# Altium schematic sheet mppt_relays.SchDoc
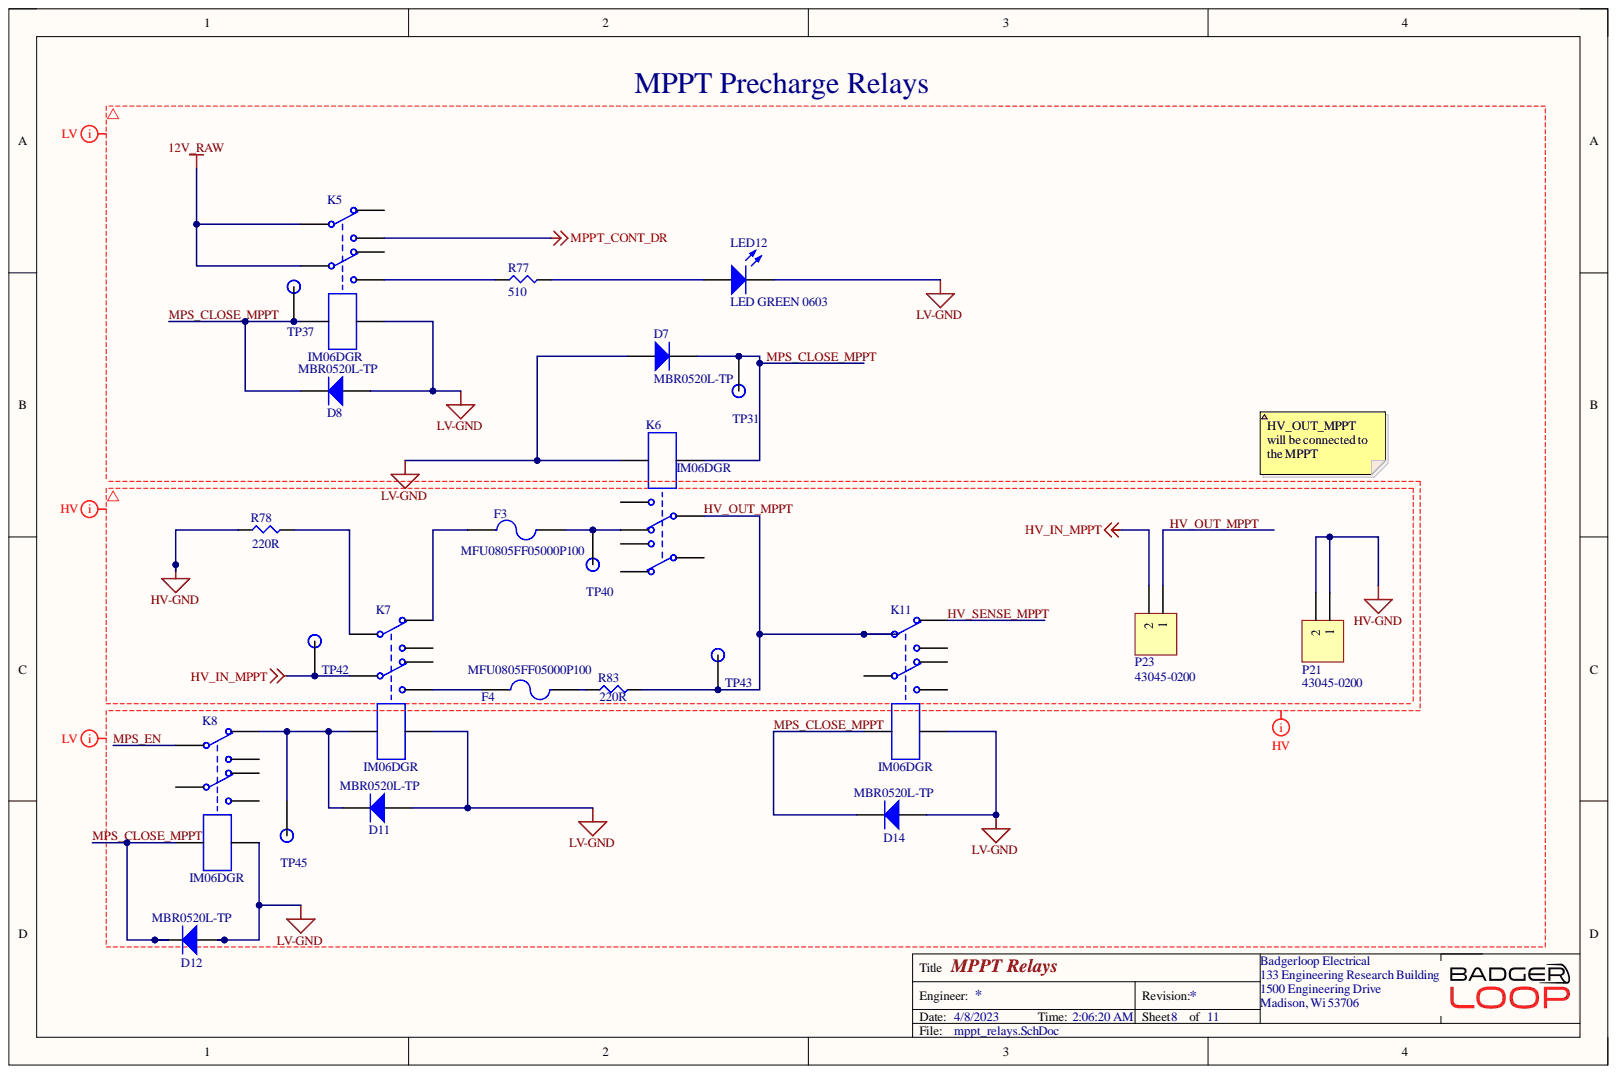
[diagram p0: mppt_relays.SchDoc]

## Parts
D7  MBR0520L-TP  [MBR0520LT1G - SOD123]
D8  MBR0520L-TP  [MBR0520LT1G - SOD123]
D11  MBR0520L-TP  [MBR0520LT1G - SOD123]
D12  MBR0520L-TP  [MBR0520LT1G - SOD123]
D14  MBR0520L-TP  [MBR0520LT1G - SOD123]
F3  MFU0805FF05000P100  [SMD-0805-RES]
F4  MFU0805FF05000P100  [SMD-0805-RES]
K5  Manufacturer Part Number: IM06DGR  [1-1462039-8 - relay]  (hidden comment: 1-1462039-8)
K6  Manufacturer Part Number: IM06DGR  [1-1462039-8 - relay]  (hidden comment: 1-1462039-8)
K7  Manufacturer Part Number: IM06DGR  [1-1462039-8 - relay]  (hidden comment: 1-1462039-8)
K8  Manufacturer Part Number: IM06DGR  [1-1462039-8 - relay]  (hidden comment: 1-1462039-8)
K11  Manufacturer Part Number: IM06DGR  [1-1462039-8 - relay]  (hidden comment: 1-1462039-8)
LED12  LED GREEN 0603  Color: GREEN  [LED 0603 GREEN]
P21  43045-0200  [430450200]
P23  43045-0200  [430450200]
R77  RMCF1206FT510R  [SMD-1206-RES]  (value not drawn)
R78  Resistance: 220R  [TO-247-2]  (hidden comment: AP101220RJ)
R83  Resistance: 220R  [TO-247-2]  (hidden comment: AP101220RJ)
TP31  TP  [Test Point]
TP37  TP  [Test Point]
TP40  TP  [Test Point]
TP42  TP  [Test Point]
TP43  TP  [Test Point]
TP45  TP  [Test Point]

## Nets
12V_RAW: K5.3 K5.6
HV-GND: P21.1 P21.2 R78.1
HV_IN_MPPT: K7.6 P23.2 TP42.1
LV-GND: D7.1 D8.1 D11.1 D12.1 D14.1 K5.8 K6.8 K7.8 K8.8 K11.8 LED12.2
MPPT_CONT_DR: K5.4
HV_OUT_MPPT: K6.6 K11.3 P23.1 R83.2 TP43.1
HV_SENSE_MPPT: K11.2
MPS_CLOSE_MPPT: D7.2 D8.2 D12.2 D14.2 K5.1 K6.1 K8.1 K11.1 TP31.1 TP37.1
MPS_EN: K8.3
NetD11_2: D11.2 K7.1 K8.2 TP45.1
NetF3_1: F3.1 K7.2
NetF3_2: F3.2 K6.7 TP40.1
NetF4_1: F4.1 K7.5
NetF4_2: F4.2 R83.1
NetK5_5: K5.5 R77.1
NetK7_3: K7.3 R78.2
NetLED12_1: LED12.1 R77.2

Not connected: K5 2 7; K6 2 3 4 5; K7 4 7; K8 4 5 6 7; K11 4 5 6 7

## Library data of the parts
D7 D8 D11 D12 D14 = MBR0520L-TP: Rectifier Diode, Schottky, 1 Phase, 1 Element, 0.5A, 20V V(RRM), Silicon; Manufacturer: MCC; Forward Voltage-Max (VF): 300mV; Max Output Current: 500mA; Max Power Dissipation: 410mW; Non-rep Pk Forward Current-Max: 5.5A; Number of Elements: 1; Package Body Material: Plastic; Qualification Status: Not Qualified; Time @ Peak Reflow Temperature-Max (s): 10s; Category: Rectifier Diodes; Component Kind: Standard; ComponentLink1Description: https://datasheet.ciiva.com:443/pdfs/VipMasterIC/IC/MCCC/MCCC-S-A0007607609/MCCC-S-A0007607609-1.pdf?src-supplier=IHS+Markit; ComponentLink1URL: https://datasheet.ciiva.com:443/pdfs/VipMasterIC/IC/MCCC/MCCC-S-A0007607609/MCCC-S-A0007607609-1.pdf?src-supplier=IHS+Markit; ComponentLink2Description: https://datasheet.ciiva.com/24106/mbr0520lmbr0540lsod-123-276512-24106653.pdf?src-supplier=Mouser; ComponentLink2URL: https://datasheet.ciiva.com/24106/mbr0520lmbr0540lsod-123-276512-24106653.pdf?src-supplier=Mouser; ComponentLink3Description: https://datasheet.ciiva.com/6381/mbr0520lmbr0540lsod-123-6381109.pdf?src-supplier=Digi-Key; ComponentLink3URL: https://datasheet.ciiva.com/6381/mbr0520lmbr0540lsod-123-6381109.pdf?src-supplier=Digi-Key; Diode Element Material: SILICON; Diode Type: RECTIFIER; JESD-30 Code: R-PDSO-G2; JESD-609 Code: e3; Library Name: misc.SchLib; Manufacturer Part Number: MBR0520L-TP; Max Operating Temperature: 125°C; Moisture Sensitivity Level: 1; Number of Pins: 2; Package Shape: Rectangular; Package Style: SMALL OUTLINEMeter; Peak Reflow Temperature (Cel): 260°C; Pricing 1: 1=0.52000, 10=0.38700, 100=0.21930, 500=0.14520, 1000=0.11132 (USD); REACH SVHC: Yes; Rep Pk Reverse Voltage-Max: 20V; RoHS: Yes; RoHS Compliant: Yes; Stock 1: 75810; Supplier 1: Digi-Key; Supplier Part Number 1: MBR0520L-TPMSCT-ND; Surface Mount: Yes; Technology: SCHOTTKY; Terminal Finish: Tin; Terminal Form: Gull Wing; Terminal Position: DUAL
F3 F4 = MFU0805FF05000P100: Electric Fuse, Very Fast Blow, 5A, 32VDC, 50A (IR), Surface Mount; Manufacturer: Vishay; Body Breadth: 1.25inch; Body Height: 0.45mm; Body Length or Diameter: 2mm; Joule-integral-Nom: 0.2275J; Reference Standard: UL; Voltage Rating (DC): 32V; Blow Characteristic: VERY FAST; Category: Fuses; Circuit Protection Type: Electric Fuse; Component Kind: Standard; ComponentLink1Description: https://datasheet.ciiva.com:443/pdfs/VipMasterIC/IC/VISH/VISH-S-A0001809179/VISH-S-A0001809179-1.pdf?src-supplier=IHS+Markit; ComponentLink1URL: https://datasheet.ciiva.com:443/pdfs/VipMasterIC/IC/VISH/VISH-S-A0001809179/VISH-S-A0001809179-1.pdf?src-supplier=IHS+Markit; ComponentLink2Description: https://datasheet.ciiva.com/1730/mfuserie-1730720.pdf?src-supplier=Newark; ComponentLink2URL: https://datasheet.ciiva.com/1730/mfuserie-1730720.pdf?src-supplier=Newark; ComponentLink3Description: https://datasheet.ciiva.com/11337/mfuserie-11337721.pdf?src-supplier=Avnet; ComponentLink3URL: https://datasheet.ciiva.com/11337/mfuserie-11337721.pdf?src-supplier=Avnet; ComponentLink4Description: https://datasheet.ciiva.com/8057/1480005-8057917.pdf?src-supplier=Element14; ComponentLink4URL: https://datasheet.ciiva.com/8057/1480005-8057917.pdf?src-supplier=Element14; ComponentLink5Description: https://datasheet.ciiva.com/22734/1480005-22734093.pdf?src-supplier=Element14; ComponentLink5URL: https://datasheet.ciiva.com/22734/1480005-22734093.pdf?src-supplier=Element14; ComponentLink6Description: https://datasheet.ciiva.com/17414/mfuserie-17414775.pdf?src-supplier=Avnet+Europe; ComponentLink6URL: https://datasheet.ciiva.com/17414/mfuserie-17414775.pdf?src-supplier=Avnet+Europe; ComponentLink7Description: https://datasheet.ciiva.com/1730/mfuserie-1730721.pdf?src-supplier=Newark; ComponentLink7URL: https://datasheet.ciiva.com/1730/mfuserie-1730721.pdf?src-supplier=Newark; Current Rating: 5A; JESD-609 Code: e3; Library Name: misc.SchLib; Manufacturer Part Number: MFU0805FF05000P100; Max Operating Temperature: 35°C; Min Operating Temperature: 15°C; Mount: Surface Mount; Packaging: Tape and Reel; Pricing 1: 1=0.49000, 5=0.47600, 10=0.46200, 50=0.38880, 100=0.32270, 250=0.29340, 500=0.24940 (USD); Rated Breaking Capacity: 50A; REACH SVHC: Yes; RoHS: Yes; RoHS Compliant: Yes; Stock 1: 50547; Supplier 1: Digi-Key; Supplier Part Number 1: MFU08055.00CT-ND; Terminal Finish: Tin; Termination: Wraparound
K5-K8 K11 = 1-1462039-8: Electromechanical Relay, DPDT, 140mW (Coil), 5A (Contact), 220VDC (Contact), Random, AC/DC Output; Manufacturer: TE Connectivity; Body Breadth: 6inch; Body Height: 5.65mm; Body Length or Diameter: 10mm; Coil Operate Voltage(DC): 9V; Coil Release Voltage(DC): 1.2V; Coil Resistance: 1.029kR; Coil Voltage-Nom: 12V; Coil/Input Supply Type: DC; Contact AC Max Power Rating R Load: 62.5VA@250VAC; Contact AC Max Rating R Load: 5A@250VAC; Contact DC Max Power Rating R Load: 60W@220VDC; Contact DC Max Rating R Load: 5A@220VDC; Contact Resistance: 50mR; Contact Voltage(AC)-Max: 250V; Contact Voltage(DC)-Max: 220V; Dielectric Strength Between Coil and Contacts: 1500Vrms; Dielectric Strength Between Open Contacts: 750Vrms; End Contact Material: Silver; End Contact Plating: Gold; Insulation Resistance: 1GR; Operate Time: 3ms; Reference Standard: UL; Relay Form: 2 FORM C; Sealing: HERMETICAL; Category: Power Relays; Coil Power: 140mW; Component Kind: Standard; ComponentLink1Description: https://datasheet.ciiva.com:443/pdfs/VipMasterIC/IC/TYEL/TYELS75403/TYELS75403-1.pdf?src-supplier=IHS+Markit; ComponentLink1URL: https://datasheet.ciiva.com:443/pdfs/VipMasterIC/IC/TYEL/TYELS75403/TYELS75403-1.pdf?src-supplier=IHS+Markit; ComponentLink2Description: https://datasheet.ciiva.com/1662/13778-1662593.pdf?src-supplier=Newark; ComponentLink2URL: https://datasheet.ciiva.com/1662/13778-1662593.pdf?src-supplier=Newark; ComponentLink3Description: https://datasheet.ciiva.com/1525/1717896-1525047.pdf?src-supplier=Element14; ComponentLink3URL: https://datasheet.ciiva.com/1525/1717896-1525047.pdf?src-supplier=Element14; ComponentLink4Description: https://datasheet.ciiva.com/1612/1717896-1612285.pdf?src-supplier=Farnell; ComponentLink4URL: https://datasheet.ciiva.com/1612/1717896-1612285.pdf?src-supplier=Farnell; ComponentLink5Description: https://datasheet.ciiva.com/30159/customerdrawing1462037-4a10-30159339.pdf?src-supplier=Onlinecomponents.com; ComponentLink5URL: https://datasheet.ciiva.com/30159/customerdrawing1462037-4a10-30159339.pdf?src-supplier=Onlinecomponents.com; ComponentLink6Description: https://datasheet.ciiva.com/22697/1717896-22697038.pdf?src-supplier=Element14; ComponentLink6URL: https://datasheet.ciiva.com/22697/1717896-22697038.pdf?src-supplier=Element14; Contact Current(AC)-Max: 5A; Contact Current(DC)-Max: 5A; Contact/Output Supply Type: AC/DC; Input Switching Control Type: Random; Library Name: misc.SchLib; Number of Pins: 8; Packaging: Tape and Reel; Pricing 1: 1=5.59000, 10=5.24500, 25=4.66200, 50=4.42880, 100=4.19580, 250=3.72960, 500=3.49650 (USD); REACH SVHC: No SVHC; Relay Function: DPDT; RoHS: No; RoHS Compliant: No; Stock 1: 35148; Supplier 1: Digi-Key; Supplier Part Number 1: PB1174CT-ND; Termination: Solder; Weight: 0.75g
LED12 = LED GREEN 0603: Manufacturer: Lite-On Inc.; Manufacturer P/N: LTST-C190KGKT; Component Kind: Standard; Digikey P/N: 160-1435-1-ND; Library Name: misc.SchLib; Package / Case: 0603
P21 P23 = CMP-002-00095-1: CONN HEADER R/A 2POS; Manufacturer: Molex; Approvals: UL,CSA,TUV; Contact Resistance: 10mR; Current Rating: 5A; Gender: Male; Glow Wire Compliant: Compliant; Insulation Resistance: 1GR; Max Voltage Rating (DC): 250.25kV; Number of Circuits: 2; Pitch: 3mm; Voltage Rating: 600V; Voltage Rating (DC): 250V; Case/Package: SMC; Color: Black; Component Kind: Standard; ComponentLink1Description: https://datasheet.ciiva.com/14155/04402310-14155203.pdf?src-supplier=Distrelec; ComponentLink1URL: https://datasheet.ciiva.com/14155/04402310-14155203.pdf?src-supplier=Distrelec; ComponentLink2Description: https://datasheet.ciiva.com/19454/883270-19454006.pdf?src-supplier=Jameco; ComponentLink2URL: https://datasheet.ciiva.com/19454/883270-19454006.pdf?src-supplier=Jameco; ComponentLink3Description: https://datasheet.ciiva.com/5150/0430450200-pcb-headers-145770-5150407.pdf?src-supplier=Mouser; ComponentLink3URL: https://datasheet.ciiva.com/5150/0430450200-pcb-headers-145770-5150407.pdf?src-supplier=Mouser; ComponentLink4Description: https://datasheet.ciiva.com/11747/0900766b80027348-11747001.pdf?src-supplier=RSComponents; ComponentLink4URL: https://datasheet.ciiva.com/11747/0900766b80027348-11747001.pdf?src-supplier=RSComponents; ComponentLink5Description: https://datasheet.ciiva.com/16656/0430450200-pcb-headers-16656330.pdf?src-supplier=Avnet+Europe; ComponentLink5URL: https://datasheet.ciiva.com/16656/0430450200-pcb-headers-16656330.pdf?src-supplier=Avnet+Europe; ComponentLink6Description: https://datasheet.ciiva.com/10090/0430450200-pcb-headers-10090299.pdf?src-supplier=Avnet; ComponentLink6URL: https://datasheet.ciiva.com/10090/0430450200-pcb-headers-10090299.pdf?src-supplier=Avnet; ComponentLink7Description: https://datasheet.ciiva.com/36854/124280430450200-pcb-headers-36854331.pdf?src-supplier=Onlinecomponents.com; ComponentLink7URL: https://datasheet.ciiva.com/36854/124280430450200-pcb-headers-36854331.pdf?src-supplier=Onlinecomponents.com; ComponentLink8Description: https://datasheet.ciiva.com/1443/1859921-1443437.pdf?src-supplier=Element14; ComponentLink8URL: https://datasheet.ciiva.com/1443/1859921-1443437.pdf?src-supplier=Element14; Contact Gender: Male; Contact Material: Brass,Tin; Contact Plating: Tin; Design Item ID: 43045-0200; Diameter: 3mm; Flammability Rating: UL94 V-0; Halogen Free: Low Halogen; Housing Color: Black; Housing Material: Thermoplastic; Lead Free: Lead Free; Library Name: Altium Concord Pro on A365; Manufacturer Part Number: 43045-0200; Material: Thermoplastic; Max Operating Temperature: 105°C; Min Operating Temperature: -40°C; Mount: Through Hole; Number of Contacts: 2; Number of Positions: 2; Number of Rows: 2; Orientation: Right Angle; Package Quantity: 3168; Packaging: Tray; Pricing 1: 1=0.97000, 10=0.84900, 100=0.73280, 500=0.59958, 1000=0.53296, 5000=0.46634, 10000=0.44302 (USD); Radiation Hardening: No; REACH SVHC: Unknown; RoHS: Yes; Row Spacing: 3mm; Source: connector.SchLib; Stock 1: 6320; Termination: Through Hole
R77 = RMCF1206FT510R: Fixed Resistor, Metal Glaze/thick Film, 0.25W, 510ohm, 200V, 1% +/-Tol, 100ppm/Cel, Surface Mount, 1206; Manufacturer: Stackpole Electronics; Package Height: 0.55mm; Package Length: 3.2mm; Package Width: 1.6mm; Rated Power Dissipation (P): 250mW; Rated Temperature: 70°C; Reference Standard: AEC-Q200; Resistance: 510; Temperature Coefficient: 100ppm/°C; Category: Chip SMD Resistors; Component Kind: Standard; ComponentLink1Description: https://datasheet.ciiva.com:443/pdfs/VipMasterIC/IC/SEIE/SEIE-S-A0009541969/SEIE-S-A0009541969-1.pdf?src-supplier=IHS+Markit; ComponentLink1URL: https://datasheet.ciiva.com:443/pdfs/VipMasterIC/IC/SEIE/SEIE-S-A0009541969/SEIE-S-A0009541969-1.pdf?src-supplier=IHS+Markit; ComponentLink2Description: http://components.arrow.com/part/dataview/40948710S9551678N1174?src-supplier=Arrow+Electronics; ComponentLink2URL: http://components.arrow.com/part/dataview/40948710S9551678N1174?src-supplier=Arrow+Electronics; ComponentLink3Description: https://www.seielect.com/Catalog/SEI-RMCF_RMCP.pdf?src-supplier=Digi-Key; ComponentLink3URL: https://www.seielect.com/Catalog/SEI-RMCF_RMCP.pdf?src-supplier=Digi-Key; ComponentLink4Description: https://www.seielect.com/catalog/SEI-Packaging.pdf?src-supplier=Digi-Key; ComponentLink4URL: https://www.seielect.com/catalog/SEI-Packaging.pdf?src-supplier=Digi-Key; ComponentLink5Description: https://www.seielect.com/catalog/SEI-RMCF_RMCP.pdf?src-supplier=Avnet; ComponentLink5URL: https://www.seielect.com/catalog/SEI-RMCF_RMCP.pdf?src-supplier=Avnet; Construction: Rectangular; JESD-609 Code: e3; Library Name: resistors.SchLib; Manufacturer Part Number: RMCF1206FT510R; Max Operating Temperature: 155°C; Min Operating Temperature: -55°C; Mount: Surface Mount; Number of Pins: 2; Package Shape: Rectangular; Package Style: SMTMeter; Packaging: Tape and Reel; Pricing 1: 1=0.10000, 10=0.03600, 100=0.01460, 1000=0.00655, 2500=0.00569 (USD); REACH SVHC: Yes; Resistor Type: FIXED RESISTOR; RoHS: Yes; RoHS Compliant: Yes; Size Code: 1206; Stock 1: 51; Supplier 1: Digi-Key; Supplier Part Number 1: RMCF1206FT510RCT-ND; Technology: METAL GLAZE/THICK FILM; Terminal Finish: Nickel; Termination: Wraparound; Tolerance: 1%; Working Voltage: 200V
R78 R83 = AP101220RJ: Fixed Resistor, 100W, 220ohm, 700V, 5% +/-Tol, 100ppm/Cel, Through Hole Mount; Manufacturer: Ohmite; Rated Power Dissipation (P): 3.5W; Rated Temperature: 25°C; Temperature Coefficient: 100ppm/°C; Category: Through-Hole Resistors; Component Kind: Standard; ComponentLink1Description: https://datasheet.ciiva.com:443/pdfs/VipMasterIC/IC/OMIT/OMIT-S-A0001298920/OMIT-S-A0001298920-1.pdf?src-supplier=IHS+Markit; ComponentLink1URL: https://datasheet.ciiva.com:443/pdfs/VipMasterIC/IC/OMIT/OMIT-S-A0001298920/OMIT-S-A0001298920-1.pdf?src-supplier=IHS+Markit; ComponentLink2Description: http://www.farnell.com/datasheets/2015590.pdf?src-supplier=Farnell; ComponentLink2URL: http://www.farnell.com/datasheets/2015590.pdf?src-supplier=Farnell; ComponentLink3Description: http://www.mouser.com/ds/2/303/acl_ap101-773331.pdf?src-supplier=Mouser; ComponentLink3URL: http://www.mouser.com/ds/2/303/acl_ap101-773331.pdf?src-supplier=Mouser; ComponentLink4Description: http://www.ohmite.com/cat/acl_ap101.pdf?src-supplier=Digi-Key; ComponentLink4URL: http://www.ohmite.com/cat/acl_ap101.pdf?src-supplier=Digi-Key; Library Name: resistors.SchLib; Manufacturer Part Number: AP101220RJ; Max Operating Temperature: 175°C; Min Operating Temperature: -65°C; Mount: Through Hole; Number of Pins: 2; Package Shape: Rectangular; Pricing 1: 1=6.63000, 10=6.21800, 35=5.80343, 70=5.11271, 105=4.42171, 245=4.00722, 455=3.73086, 945=3.67501 (USD); REACH SVHC: Yes; Resistor Type: FIXED RESISTOR; RoHS: Yes; RoHS Compliant: Yes; Stock 1: 44; Supplier 1: Digi-Key; Supplier Part Number 1: AP101220RJ-ND; Termination: Flat; Tolerance: 5%; Working Voltage: 700V
TP31 TP37 TP40 TP42 TP43 TP45 = TP: SMD TEST POINT; Component Kind: Standard; Library Name: misc.SchLib
